# Altium schematic sheet sub.SchDoc(sub)
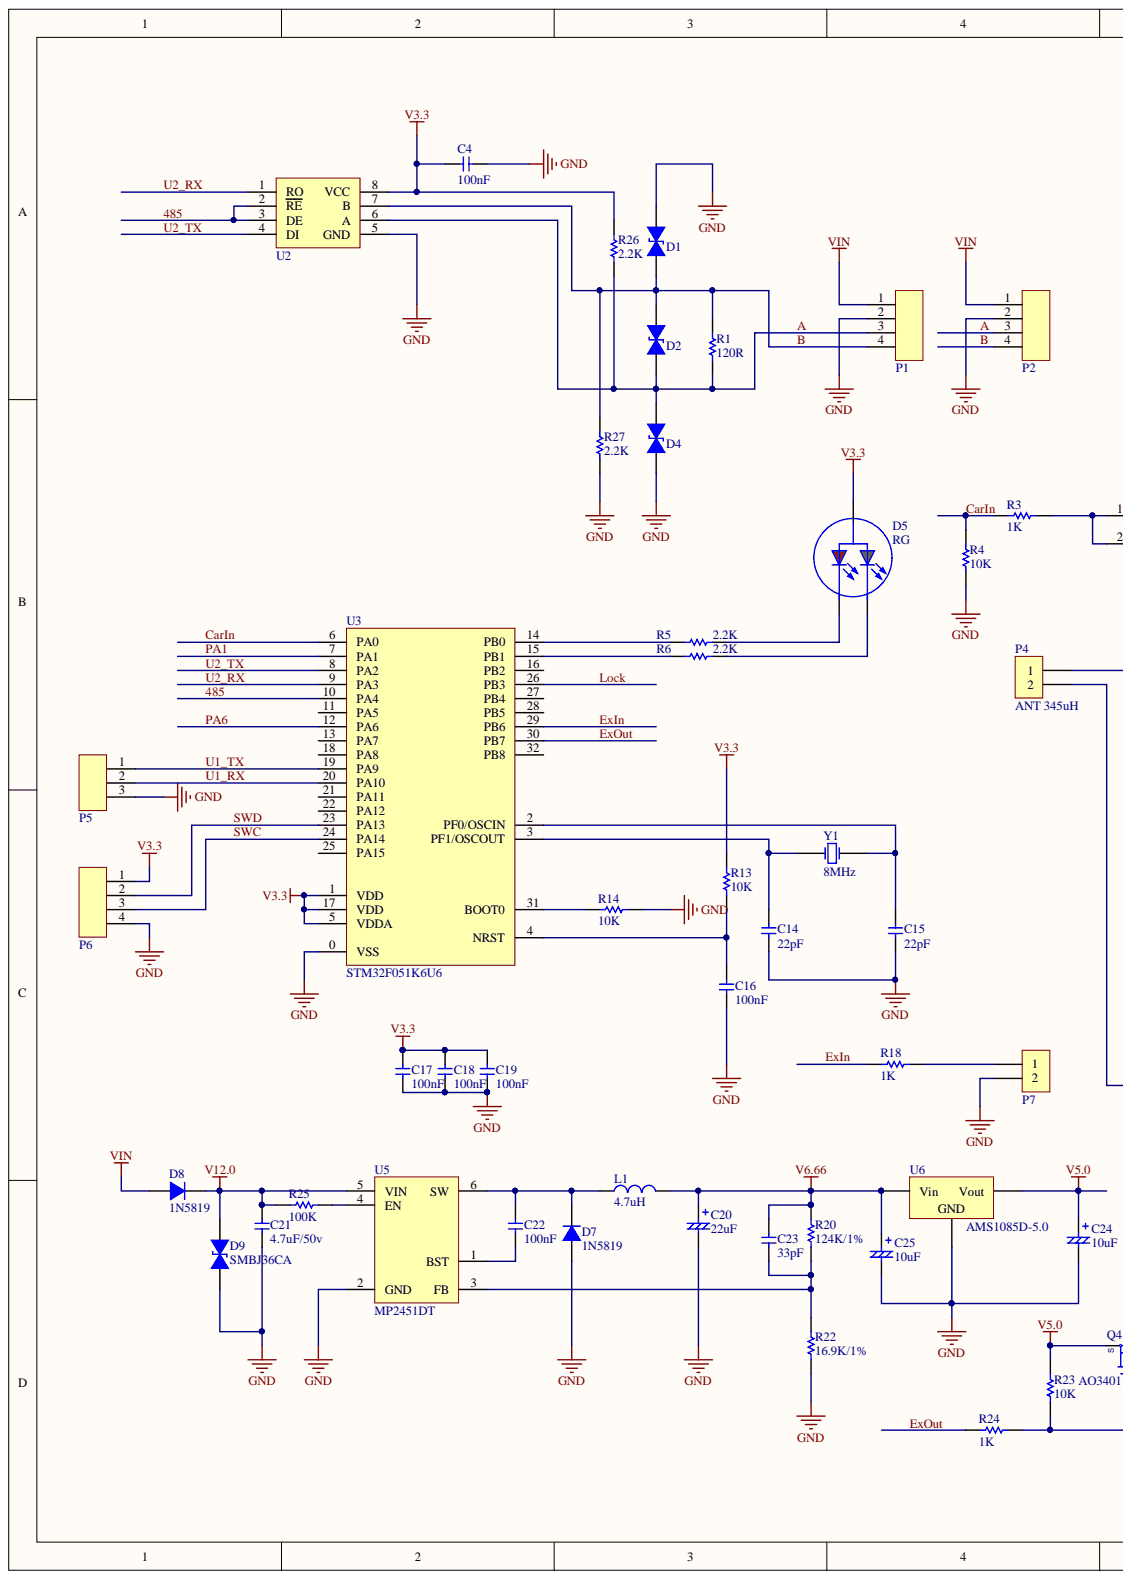
[diagram p0: sub.SchDoc, left]
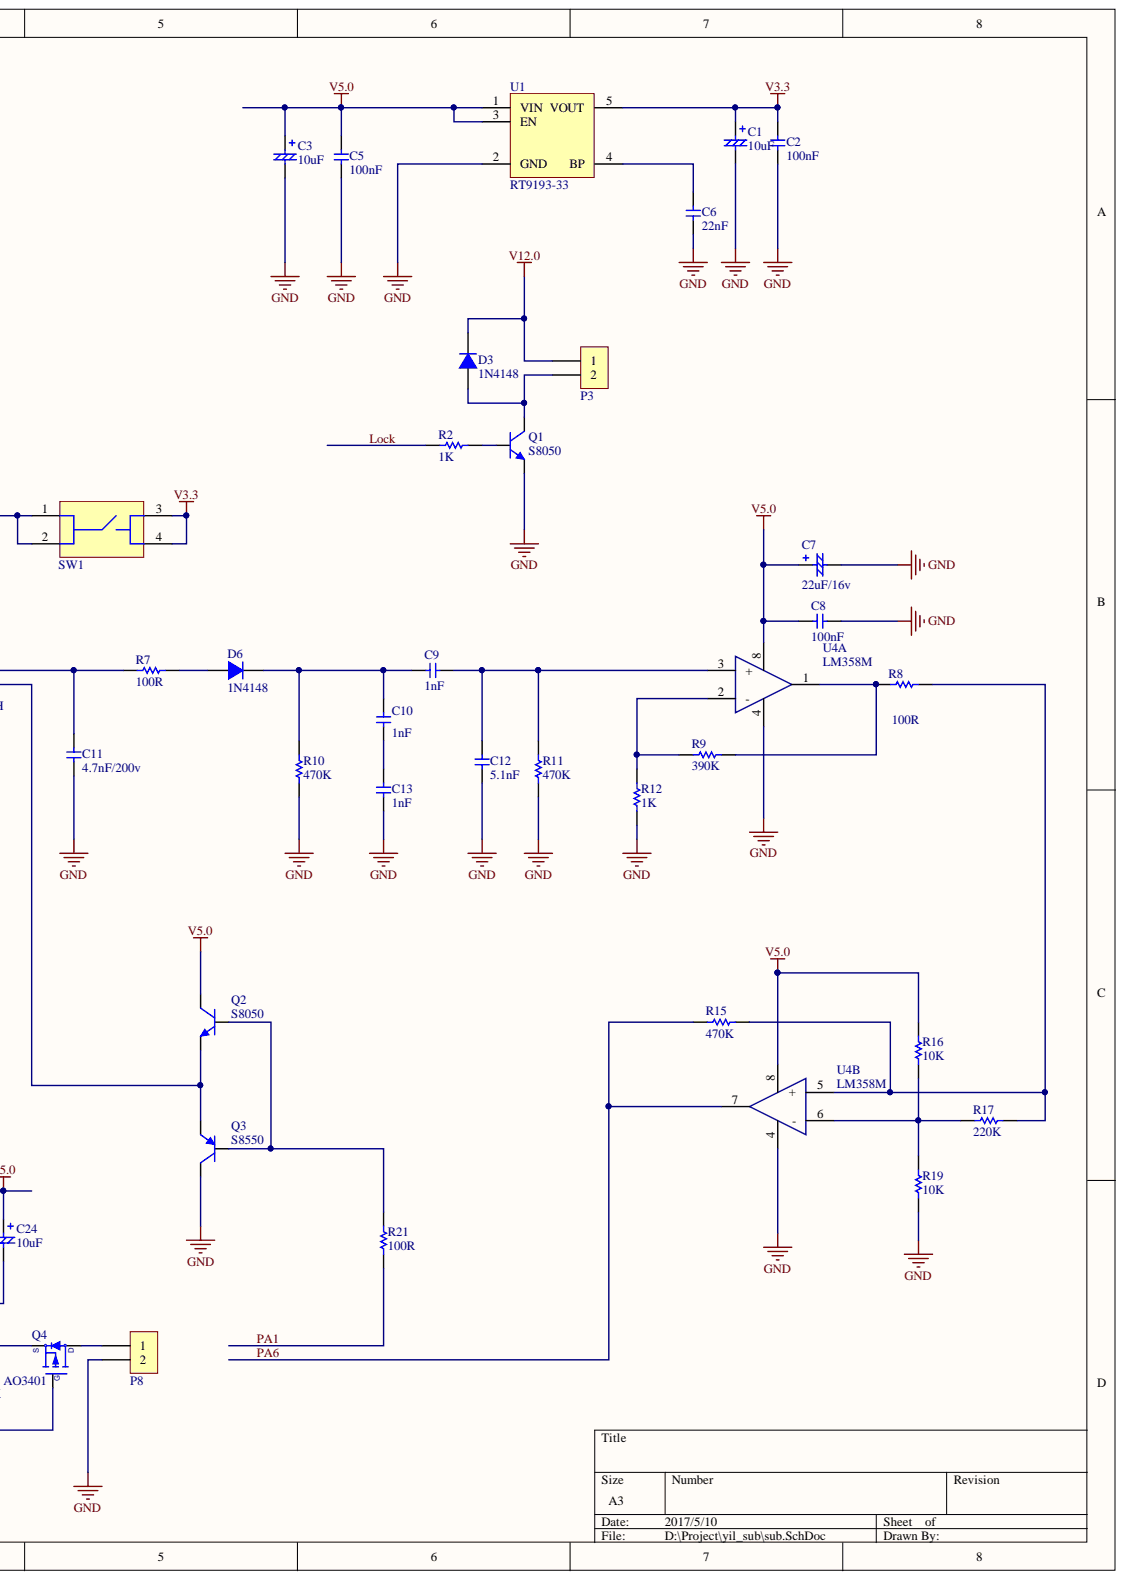
[diagram p1: sub.SchDoc, right]

## Parts
C1  10uF  [Atype]
C2  100nF  [0603C]
C3  10uF  [Atype]
C4  100nF  [0603C]
C5  100nF  [0603C]
C6  22nF  [0603C]
C7  22uF/16v  [Atype]
C8  100nF  [0603C]
C9  1nF  [0603C]
C10  1nF  [0603C]
C11  4.7nF/200v  [1206C]
C12  5.1nF  [0603C]
C13  1nF  [0603C]
C14  22pF  [0603C]
C15  22pF  [0603C]
C16  100nF  [0603C]
C17  100nF  [0603C]
C18  100nF  [0603C]
C19  100nF  [0603C]
C20  22uF  [Atype]
C21  4.7uF/50v  [1206C]
C22  100nF  [0603C]
C23  33pF  [0603C]
C24  10uF  [Atype]
C25  10uF  [Atype]
D1  TVS_CA  [SMA_TVS_CA]  (value not drawn)
D2  TVS_CA  [SMA_TVS_CA]  (value not drawn)
D3  1N4148  [MiniMELF]
D4  TVS_CA  [SMA_TVS_CA]  (value not drawn)
D5  RG  [LED_Dual]
D6  1N4148  [MiniMELF]
D7  1N5819  [SMA]
D8  1N5819  [SMA]
D9  SMBJ36CA  [SMA_TVS_CA]
L1  4.7uH  [CDRH4D28]
P1  P4  [P4_XH2.54]  (value not drawn)
P2  P4  [P4_XH2.54]  (value not drawn)
P3  P2  [P2-XH2.54]  (value not drawn)
P4  ANT 345uH  [P2-XH2.54]
P5  P3  [P3_H2.54]  (value not drawn)
P6  P4  [P4_H2.54]  (value not drawn)
P7  P2  [P2-XH2.54]  (value not drawn)
P8  P2  [P2-XH2.54]  (value not drawn)
Q1  S8050  [SOT23-3]
Q2  S8050  [SOT23-3]
Q3  S8550  [SOT23-3]
Q4  AO3401  [SOT23-3]
R1  120R  [1206R]
R2  1K  [0603R]
R3  1K  [0603R]
R4  10K  [0603R]
R5  2.2K  [0603R]
R6  2.2K  [0603R]
R7  100R  [1206R]
R8  100R  [0603R]
R9  390K  [0603R]
R10  470K  [0603R]
R11  470K  [0603R]
R12  1K  [0603R]
R13  10K  [0603R]
R14  10K  [0603R]
R15  470K  [0603R]
R16  10K  [0603R]
R17  220K  [0603R]
R18  1K  [0603R]
R19  10K  [0603R]
R20  124K/1%  [0603R]
R21  100R  [0603R]
R22  16.9K/1%  [0603R]
R23  10K  [0603R]
R24  1K  [0603R]
R25  100K  [0603R]
R26  2.2K  [0603R]
R27  2.2K  [0603R]
SW1  KFC-V-105  [KFC-V-105]  (value not drawn)
U1  RT9193-33  [SOT23-5]
U2  SP3485EEN  [SOP_8]  (value not drawn)
U3  STM32F051K6U6  [UFQFPN32_0.5]
U4  LM358M  [SOP_8]  (parts A,B)
U5  MP2451DT  [SOT23-6]
U6  AMS1085D-5.0  [TO-252-2]
Y1  8MHz  [X5032SMD]

## Nets
GND: C1.2 C2.1 C3.2 C4.1 C5.1 C6.1 C7.2 C8.1 C11.1 C12.2 C13.1 C14.1 C15.1 C16.2 C17.2 C18.2 C19.2 C20.2 C21.1 C24.2 C25.2 D1.1 D4.2 D7.2 D9.2 P1.2 P2.2 P5.3 P6.4 P7.2 P8.2 Q1.2 Q3.3 R4.1 R10.2 R11.2 R12.2 R14.2 R19.2 R22.1 R27.1 U1.2(GND) U2.5(GND) U3.0(VSS) U4.4 U5.2(GND) U6.1
V3.3: C1.1 C2.2 C4.2 C17.1 C18.1 C19.1 D5.2 P6.1 R13.2 R26.2 SW1.3 SW1.4 U1.5(VOUT) U2.8(VCC) U3.1(VDD) U3.5(VDDA) U3.17(VDD)
V5.0: C3.1 C5.2 C7.1 C8.2 C24.1 Q2.3 Q4.2 R16.1 R23.1 U1.1(VIN) U1.3(EN) U4.8 U6.2
V6.66: C20.1 C23.2 C25.1 L1.2 R20.2 U6.3
V12.0: C21.2 D3.1 D8.1 D9.1 P3.1 R25.1 U5.5(VIN)
VIN: D8.2 P1.1 P2.1
485: U2.2(RE) U2.3(DE) U3.10(PA4)
A: D2.2 D4.1 P1.3 P2.3 R1.1 R26.1 U2.6(A)
B: D1.2 D2.1 P1.4 P2.4 R1.2 R27.2 U2.7(B)
CarIn: R3.2 R4.2 U3.6(PA0)
ExIn: R18.2 U3.29(PB6)
ExOut: R24.2 U3.30(PB7)
Lock: R2.1 U3.26(PB3)
PA1: R21.1 U3.7(PA1)
PA6: R15.2 U3.12(PA6) U4.7
SWC: P6.3 U3.24(PA14)
SWD: P6.2 U3.23(PA13)
U1_RX: P5.2 U3.20(PA10)
U1_TX: P5.1 U3.19(PA9)
U2_RX: U2.1(RO) U3.9(PA3)
U2_TX: U2.4(DI) U3.8(PA2)
NetC6_2: C6.2 U1.4(BP)
NetC9_1: C9.1 C12.1 R11.1 U4.3(+)
NetC9_2: C9.2 C10.2 D6.1 R10.1
NetC10_1: C10.1 C13.2
NetC11_2: C11.2 P4.1 R7.1
NetC14_2: C14.2 U3.3(PF1/OSCOUT) Y1.1
NetC15_2: C15.2 U3.2(PF0/OSCIN) Y1.2
NetC16_1: C16.1 R13.1 U3.4(NRST)
NetC22_1: C22.1 U5.1(BST)
NetC22_2: C22.2 D7.1 L1.1 U5.6(SW)
NetC23_1: C23.1 R20.1 R22.2 U5.3(FB)
NetD3_2: D3.2 P3.2 Q1.3
NetD5_1: D5.1 R5.2
NetD5_3: D5.3 R6.2
NetD6_2: D6.2 R7.2
NetP4_2: P4.2 Q2.2 Q3.2
NetP7_1: P7.1 R18.1
NetP8_1: P8.1 Q4.3
NetQ1_1: Q1.1 R2.2
NetQ2_1: Q2.1 Q3.1 R21.2
NetQ4_1: Q4.1 R23.2 R24.1
NetR3_1: R3.1 SW1.1 SW1.2
NetR5_1: R5.1 U3.14(PB0)
NetR6_1: R6.1 U3.15(PB1)
NetR8_1: R8.1 R15.1 R17.1 U4.5(+)
NetR8_2: R8.2 R9.1 U4.1
NetR9_2: R9.2 R12.1 U4.2(-)
NetR14_1: R14.1 U3.31(BOOT0)
NetR16_2: R16.2 R17.2 R19.1 U4.6(-)
NetR25_2: R25.2 U5.4(EN)

Not connected: U3 11(PA5) 13(PA7) 16(PB2) 18(PA8) 21(PA11) 22(PA12) 25(PA15) 27(PB4) 28(PB5) 32(PB8)

## Library data of the parts
C1 C3 C7 C20 C24 C25 = CAPT: Library Name: Int_lib.IntLib; Component Kind: Standard
C2 C4-C6 C8-C19 C21-C23 = CAP: Library Name: Int_lib.IntLib; Component Kind: Standard
D3 D6-D8 = Diode: Library Name: Int_lib.IntLib; Component Kind: Standard
D5 = LED_Dual: Library Name: Int_lib.IntLib; Component Kind: Standard
D9 = TVS_CA: Library Name: Int_lib.IntLib; Component Kind: Standard
L1 = Induct: Library Name: Int_lib.IntLib; Component Kind: Standard
P4 = P2: Library Name: Int_lib.IntLib; Component Kind: Standard
Q4 = PMosFet: Library Name: Int_lib.IntLib; Component Kind: Standard
R1-R27 = Res: Library Name: Int_lib.IntLib; Component Kind: Standard
U1 = RT9193: Library Name: Int_lib.IntLib; Component Kind: Standard
U2 = MAX485: Library Name: Int_lib.IntLib; Component Kind: Standard
U3 = STM32F051KxU: Library Name: Int_lib.IntLib; Component Kind: Standard
U4 = LM358: Library Name: Int_lib.IntLib; Component Kind: Standard
U5 = MP2451Dx: Library Name: Int_lib.IntLib; Component Kind: Standard
U6 = AMS1085: Library Name: Int_lib.IntLib; Component Kind: Standard
Y1 = XTAL: Library Name: Int_lib.IntLib; Component Kind: Standard
D1 D2 D4 = TVS_CA: Library Name: Int_lib.IntLib; Component Kind: Standard
P1 P2 P6 = P4: Library Name: Int_lib.IntLib; Component Kind: Standard
P3 P7 P8 = P2: Library Name: Int_lib.IntLib; Component Kind: Standard
P5 = P3: Library Name: Int_lib.IntLib; Component Kind: Standard
Q1 Q2 = S8050: Library Name: Int_lib.IntLib; Component Kind: Standard
Q3 = S8550: Library Name: Int_lib.IntLib; Component Kind: Standard
SW1 = KFC-V-105: Library Name: Int_lib.IntLib; Component Kind: Standard
